# Altium schematic sheet Extra4_trigger.SchDoc
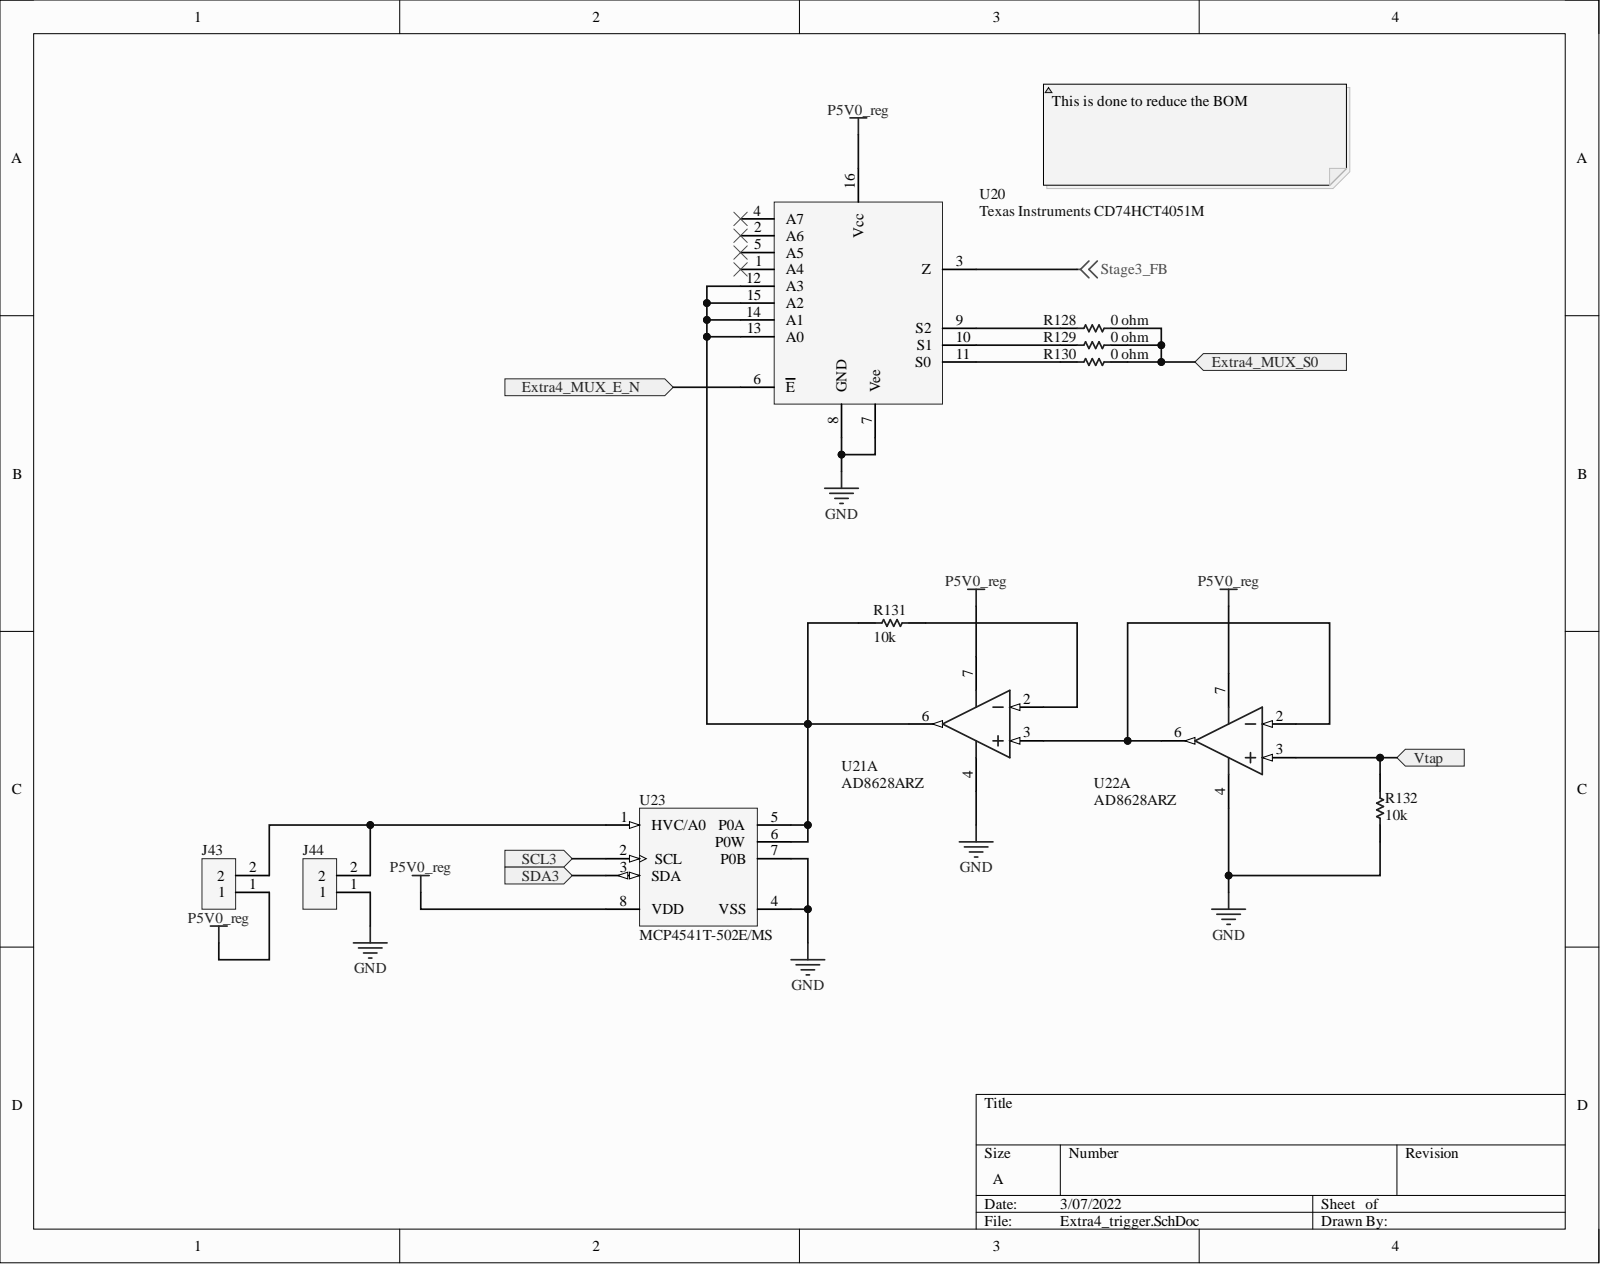
[diagram p0: Extra4_trigger.SchDoc]

## Parts
J43  (no value)  [PCB-omgsbkhp90n7lpri88og-1]
J44  (no value)  [PCB-omgsbkhp90n7lpri88og-1]
R128  0 ohm  [RESC2013X50X30LL20T20]
R129  0 ohm  [RESC2013X50X30LL20T20]
R130  0 ohm  [RESC2013X50X30LL20T20]
R131  10k  [RESC2013X55X40NL15T20]
R132  10k  [RESC2013X55X40NL15T20]
U20  Texas Instruments CD74HCT4051M  [PCB-2tkut4cattjoiggpznnl-1]
U21  AD8628ARZ  [ADI-R-8_L]  (part A)
U22  AD8628ARZ  [ADI-R-8_L]  (part A)
U23  MCP4541T-502E/MS  [MSOP-MS8_N]

## Ports
Extra4_MUX_E_N, Extra4_MUX_S0, SCL3, SDA3, Vtap

## Nets
GND: J44.1 R132.1 U20.7(Vee) U20.8(GND) U21.4 U22.4 U23.4(VSS) U23.7(P0B)
P5V0_reg: J43.1 U20.16(Vcc) U21.7 U22.7 U23.8(VDD)
Stage3_FB: U20.3(Z)
Extra4_MUX_E_N: U20.6(E) ; port Extra4_MUX_E_N
Extra4_MUX_S0: R128.2 R129.2 R130.2 ; port Extra4_MUX_S0
SCL3: U23.2(SCL) ; port SCL3
SDA3: U23.3(SDA) ; port SDA3
Vtap: R132.2 U22.3 ; port Vtap
NetJ43_2: J43.2 J44.2 U23.1(HVC/A0)
NetR128_1: R128.1 U20.9(S2)
NetR129_1: R129.1 U20.10(S1)
NetR130_1: R130.1 U20.11(S0)
NetR131_1: R131.1 U20.12(A3) U20.13(A0) U20.14(A1) U20.15(A2) U21.6 U23.5(P0A) U23.6(P0W)
NetR131_2: R131.2 U21.2
NetU21_3: U21.3 U22.2 U22.6

Not connected: U20 1(A4) 2(A6) 4(A7) 5(A5)

## Library data of the parts
J43 J44 = CMP-748fbcb73d6bab48-30: Pitch 2.54 mm, Single Row, 2pins; Component Kind: Standard; Library Name: My Personal Space
R128-R130 = CMP-1904075-2: RES SMD 0.0 OHM JUMPER 1/8W 0805; Manufacturer Part Number: CRCW08050000ZSTA; Case/Package (SI): 2012; Lead-Free Status: Contains Lead; Power Dissipation: 0.125 W; Resistance: 0.0 Ω; Resistance Tolerance: ±1%; Voltage Rating (DC): 150.0 V; Component Kind: Standard; Library Name: My Personal Space; Mounting Style: Surface Mount; Number of Pins: 2; Octopart Url: https://octopart.com/crcw08050000zsta-vishay-39388113; OctopartID: 3b91f0c21d26e456; Power Rating: 0.125 W; REACH SVHC Compliance: No SVHC; RoHS: Non-Compliant; Size-Height: 0.00045 m; Size-Length: 0.002 m; Size-Width: 0.00125 m
R131 R132 = CMP-1953953-14: RES SMD 1M OHM 0.1% 1/8W 0805; Manufacturer Part Number: TNPW080510K0BEEN; Case/Package (SI): 2012; Lead-Free Status: Lead Free; Power Rating: 0.125 W; Resistance: 7500000 Ω; Temperature Coefficient: 25.0 ppm/°C; Voltage Rating (DC): 150.0 V; Case/Package: 0805; Component Kind: Standard; Library Name: My Personal Space; Number of Pins: 2; OctopartID: 2ed9e58acfb9f11e; Packaging: Tape & Reel (TR); Resistance Tolerance: ±0.1%; RoHS: Compliant
U20 = CMP-efcb26c6fc72300c-3: High Speed CMOS 8-Channel Analog Multiplexer/Demultiplexer with TTL inputs 16-SOIC -55 to 125; Lead-Free Status: Lead Free; Number of Bits: 8; Number of Circuits: 1; Polarity: Non-Inverting; Supply Current: 16.0 µA; Supply Voltage (DC): 5.00 V; Case/Package: SOIC; Component Kind: Standard; Library Name: My Personal Space; Lifecycle Status: Active; Manufacturer Part Number: CD74HCT4051M; Mounting Style: Surface Mount; Number of Channels: 8; Number of Outputs: 8; Number of Pins: 16; Octopart URL: http://octopart.com/cd74hct4051m-texas+instruments-472799; OctopartID: efcb26c6fc72300c; Packaging: Tube; REACH SVHC Compliance: No SVHC; RoHS: Compliant
U21 U22 = CMP-2260891-3: Single Channel RRIO Operational Amplifier, 2.5 MHz BW, 1 V/us SR, Industrial, 8-pin SOIC (R-8), Tube; Bandwidth: 2500000.0 Hz; Common Mode Rejection Ratio: 140.0 dB; Input Capacitance: 1e-11 F; Input Impedance: 5e+11 Ω; Lead-Free Status: Contains Lead; Mil-Spec: No; Number of Channels: 1; Slew Rate: 1.0 V/μs; Supply Current: 0.0011 A; Supply Voltage (DC): 5.0 V; Case/Package: SOIC; Component Kind: Standard; Gain Bandwidth Product: 2500000.0 Hz; Library Name: My Personal Space; Lifecycle Status: Active; Manufacturer Part Number: AD8628ARZ; Mounting Style: Surface Mount; Number of Circuits: 1; Number of Pins: 8; Octopart Url: https://octopart.com/ad8628arz-analog+devices-412641; OctopartID: cd22042ea3a512e5; Packaging: Tube; REACH SVHC Compliance: No SVHC; RoHS: Compliant
U23 = CMP-1074023-3: 7-Bit Single I2C Digital POT with Non-Volatile Memory, 8-pin MSOP, Extended Temperature, Tape and Reel; Resistance Tolerance: ±20%; Component Kind: Standard; Library Name: My Personal Space; Lifecycle Status: Active; Manufacturer Part Number: MCP4541T-502E/MS; Octopart Url: https://octopart.com/mcp4541t-502e%2Fms-microchip-16787150; OctopartID: 3faff7a53c07e068; RoHS: Compliant
